# Altium schematic sheet BUS_BAR.SchDoc(BUS_BAR)
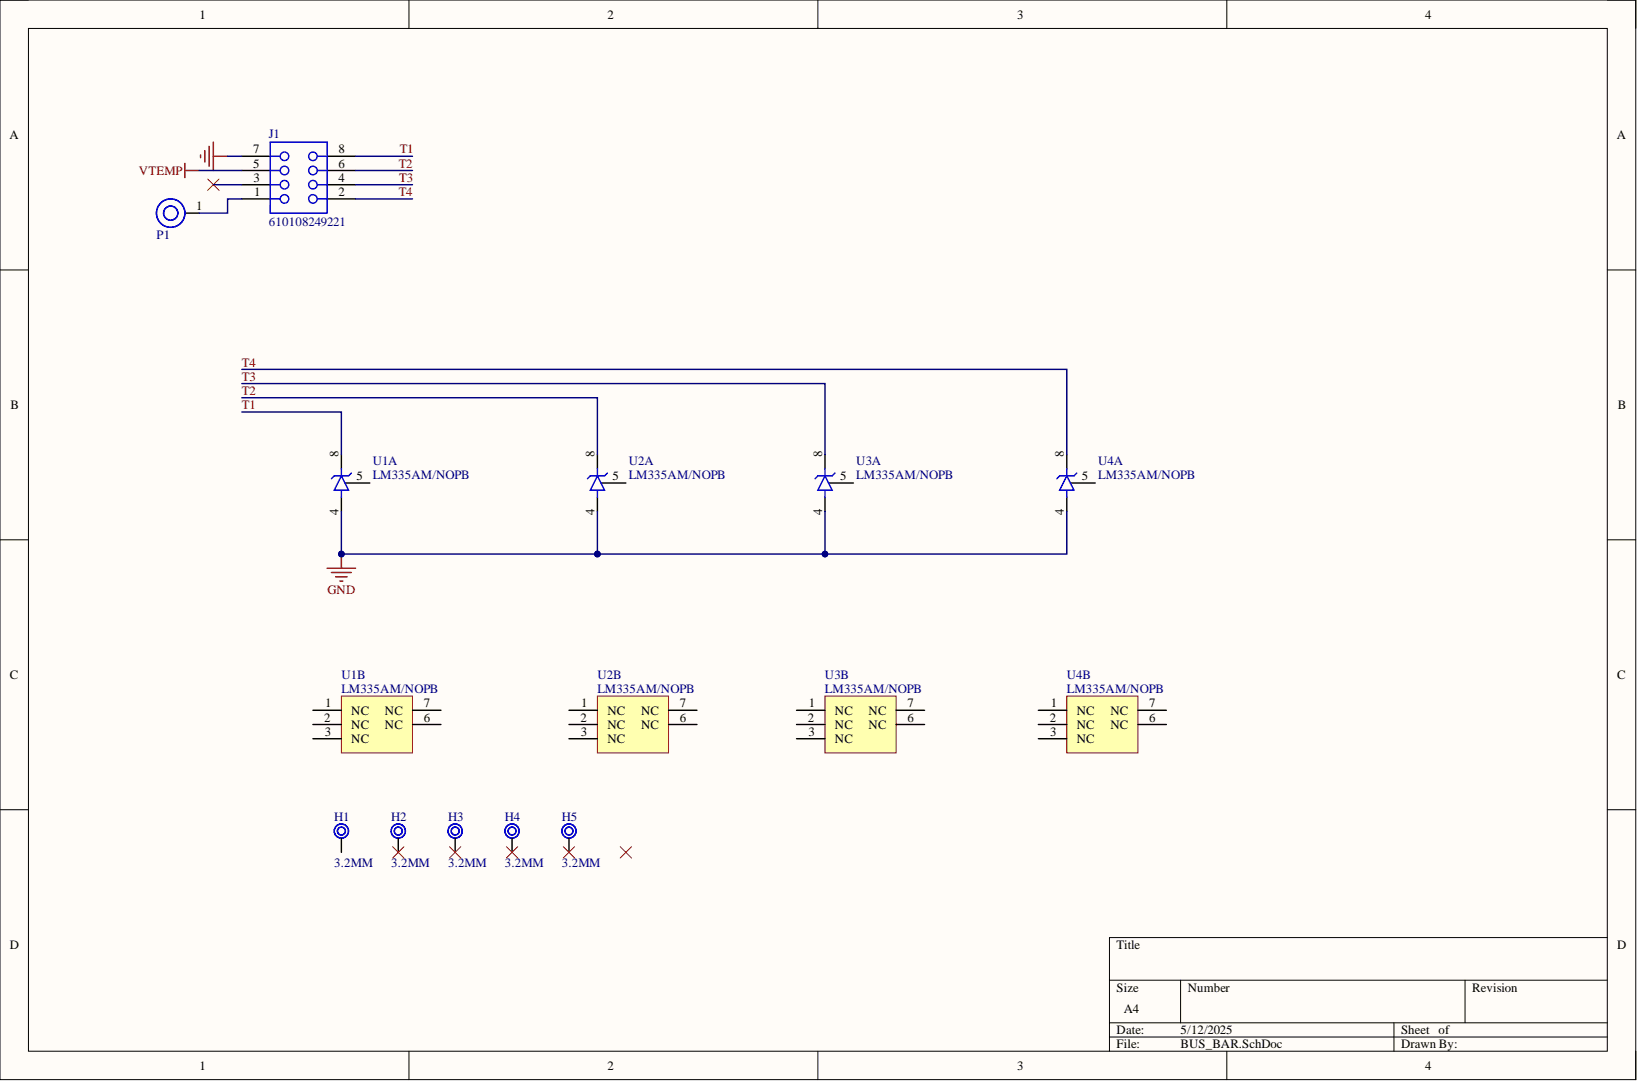
[diagram p0: BUS_BAR.SchDoc]

## Parts
H1  3.2MM
H2  3.2MM
H3  3.2MM
H4  3.2MM
H5  3.2MM
J1  610108249221
P1  THT 0.8 pinheader  (value not drawn)
U1  LM335AM/NOPB  (parts A,B)
U2  LM335AM/NOPB  (parts A,B)
U3  LM335AM/NOPB  (parts A,B)
U4  LM335AM/NOPB  (parts A,B)

## Nets
GND: J1.7 U1.4 U2.4 U3.4 U4.4
VTEMP: J1.5
T1: J1.8 U1.8
T2: J1.6 U2.8
T3: J1.4 U3.8
T4: J1.2 U4.8
NetJ1_1: J1.1 P1.1

Not connected: H1 1; H2 1; H3 1; H4 1; H5 1; J1 3; U1 1(NC) 2(NC) 3(NC) 5 6(NC) 7(NC); U2 1(NC) 2(NC) 3(NC) 5 6(NC) 7(NC); U3 1(NC) 2(NC) 3(NC) 5 6(NC) 7(NC); U4 1(NC) 2(NC) 3(NC) 5 6(NC) 7(NC)

## Library data of the parts
J1 = CMP-002-00118-1: Headers and Edge Type Connector; Connector Type: BOARD CONNECTOR; Component Kind: Standard; RoHS Compliant: Yes; Library Name: Dalhousie Formula SAE
P1 = CMP-001-0003-1: THT 0.8 Hole; Component Kind: Standard; Library Name: Dalhousie Formula SAE
U1-U4 = CMP-010-00024-1: Analog Voltage Output Sensor, 2.95V Min, 3.01V Max, 1Cel, Bipolar, Rectangular, 8 Pin, Surface Mount; Accuracy-Max (Cel): 1°C; Body Breadth: 3.9inch; Body Height: 1.55mm; Body Length or Diameter: 4.9mm; Linearity (Cel): 1.5°C; Operating Current-Max: 10mA; Package Body Material: Plastic; Package Equivalence Code: SOP8,.25; Sensor Type: TEMPERATURE SENSOR,ANALOG,VOLTAGE OUTPUT; Component Kind: Standard; Housing Material: Plastic; JESD-609 Code: e3; Max Operating Temperature: 100°C; Max Output Voltage: 3.01V; Min Operating Temperature: -40°C; Min Output Voltage: 2.95V; Mounting Technology: Surface Mount; Package Shape/Style: Rectangular; Pins: 8; Reach SVHC Compliant: Yes; RoHS Compliant: Yes; Technology: BIPOLAR; Terminal Finish: Tin; Termination: Solder; Library Name: Dalhousie Formula SAE
H1-H5 = 3.2MM: Component Kind: Standard; Library Name: Schlib1.SchLib
